# Altium schematic sheet pwrCoreSec.SchDoc(pwrCoreSec)
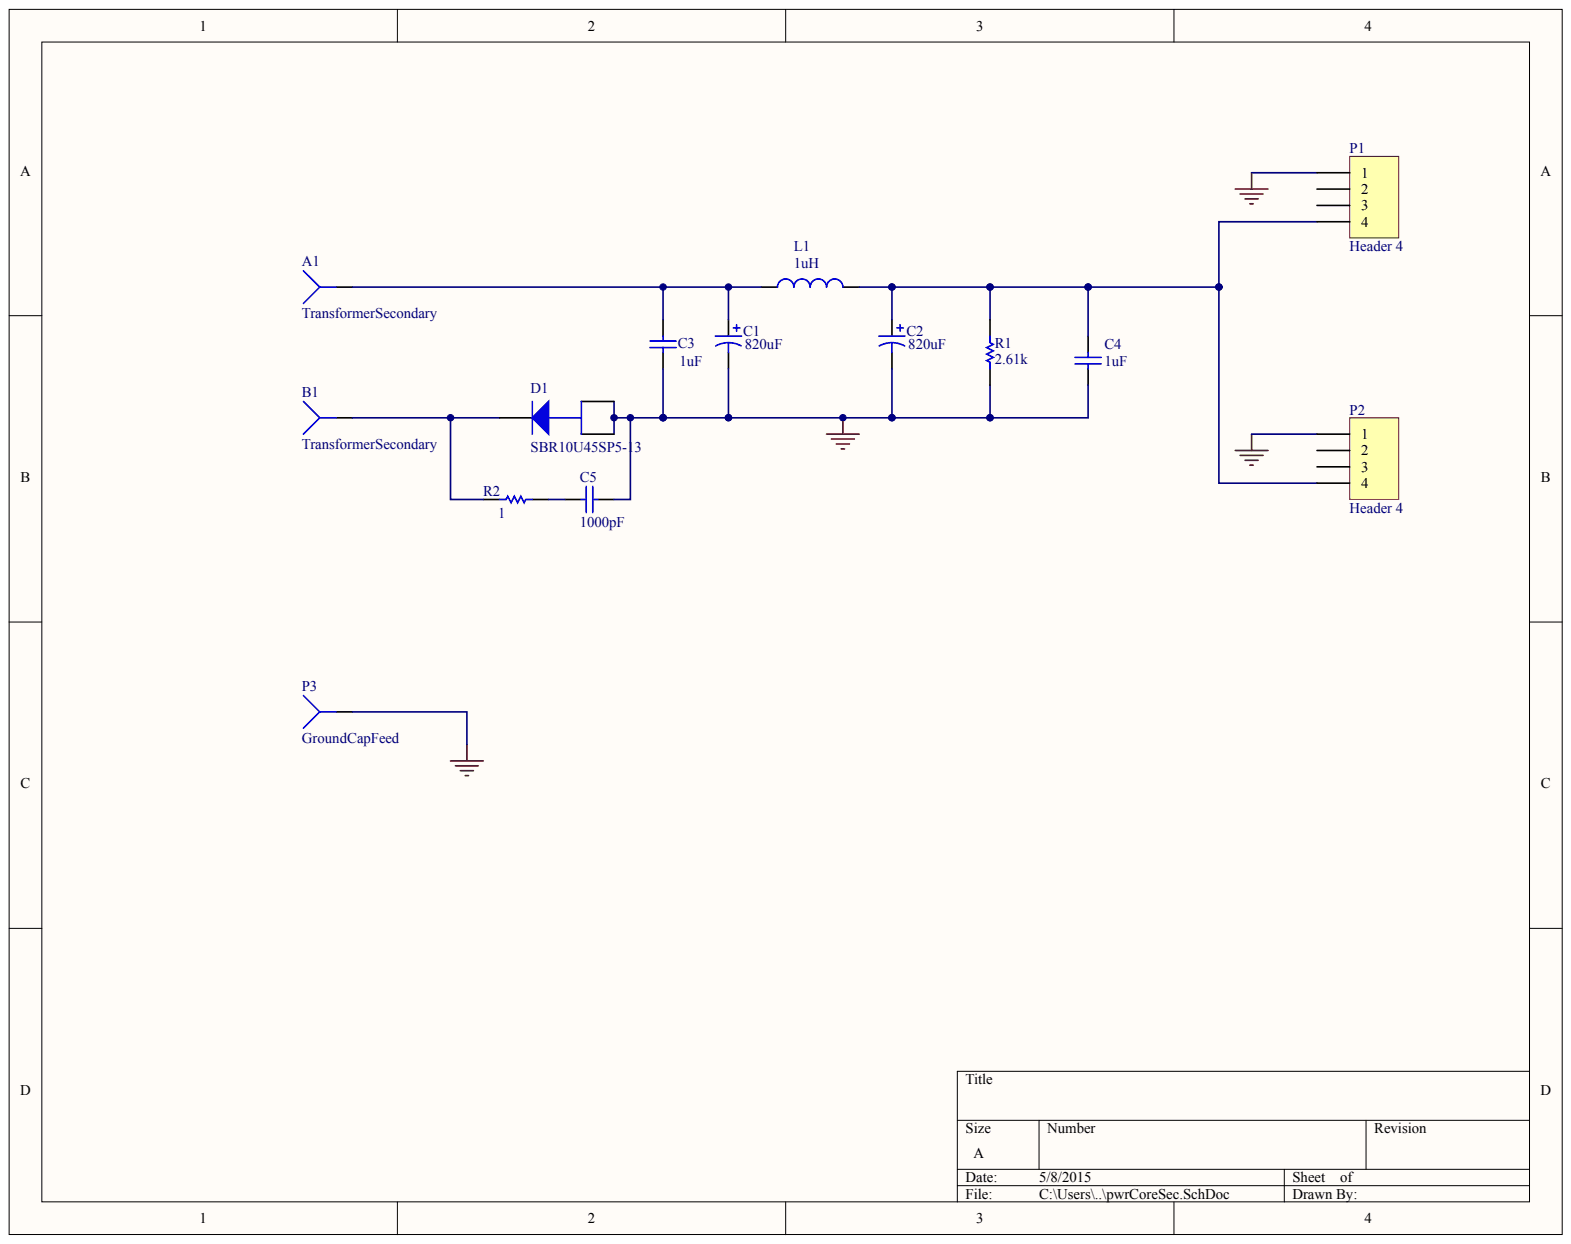
[diagram p0: pwrCoreSec.SchDoc]

## Parts
A1  TransformerSecondary
B1  TransformerSecondary
C1  value 820uF  (hidden comment: RL80J821MDN1KX)
C2  value 820uF  (hidden comment: RL80J821MDN1KX)
C3  value 1uF  (hidden comment: smd_capacitor)
C4  value 1uF  (hidden comment: smd_capacitor)
C5  value 1000pF  (hidden comment: smd_capacitor)
D1  SBR10U45SP5-13
L1  value 1uH  (hidden comment: XFL3012-152MEB)
P1  Header 4
P2  Header 4
P3  GroundCapFeed
R1  value 2.61k  (hidden comment: smd_resistor)
R2  value 1  (hidden comment: smd_resistor)

## Nets
GND: C1.2 C2.2 C3.1 C4.1 C5.1 D1.1 D1.2 P1.1 P2.1 P3.1 R1.1
NetA1_1: A1.1 C1.1 C3.2 L1.1
NetB1_1: B1.1 D1.3 R2.2
NetC2_1: C2.1 C4.2 L1.2 P1.4 P2.4 R1.2
NetC5_2: C5.2 R2.1

Not connected: P1 2 3; P2 2 3

## Library data of the parts
A1 B1 C1-C5 D1 L1 P1-P3 R1 R2 = (no symbol name)
  C1 C2: Digikey: 493-4023-3-ND
  C3 C4: Digikey: 445-4978-1-ND
  C5: Digikey: 490-1303-1-ND
  D1: Digikey: SBR10U45SP5-13DICT-ND
  P1 P2: LatestRevisionDate: 17-Jul-2002; LatestRevisionNote: Re-released for DXP Platform.; Digikey: S7002-ND; Publisher: Altium Limited
  R1: Digikey: 541-2.61KLCT-ND
  R2: Digikey: RMCF0805JT1R00CT-ND
